# Altium schematic sheet FinalYear_Project_PCB.SchDoc
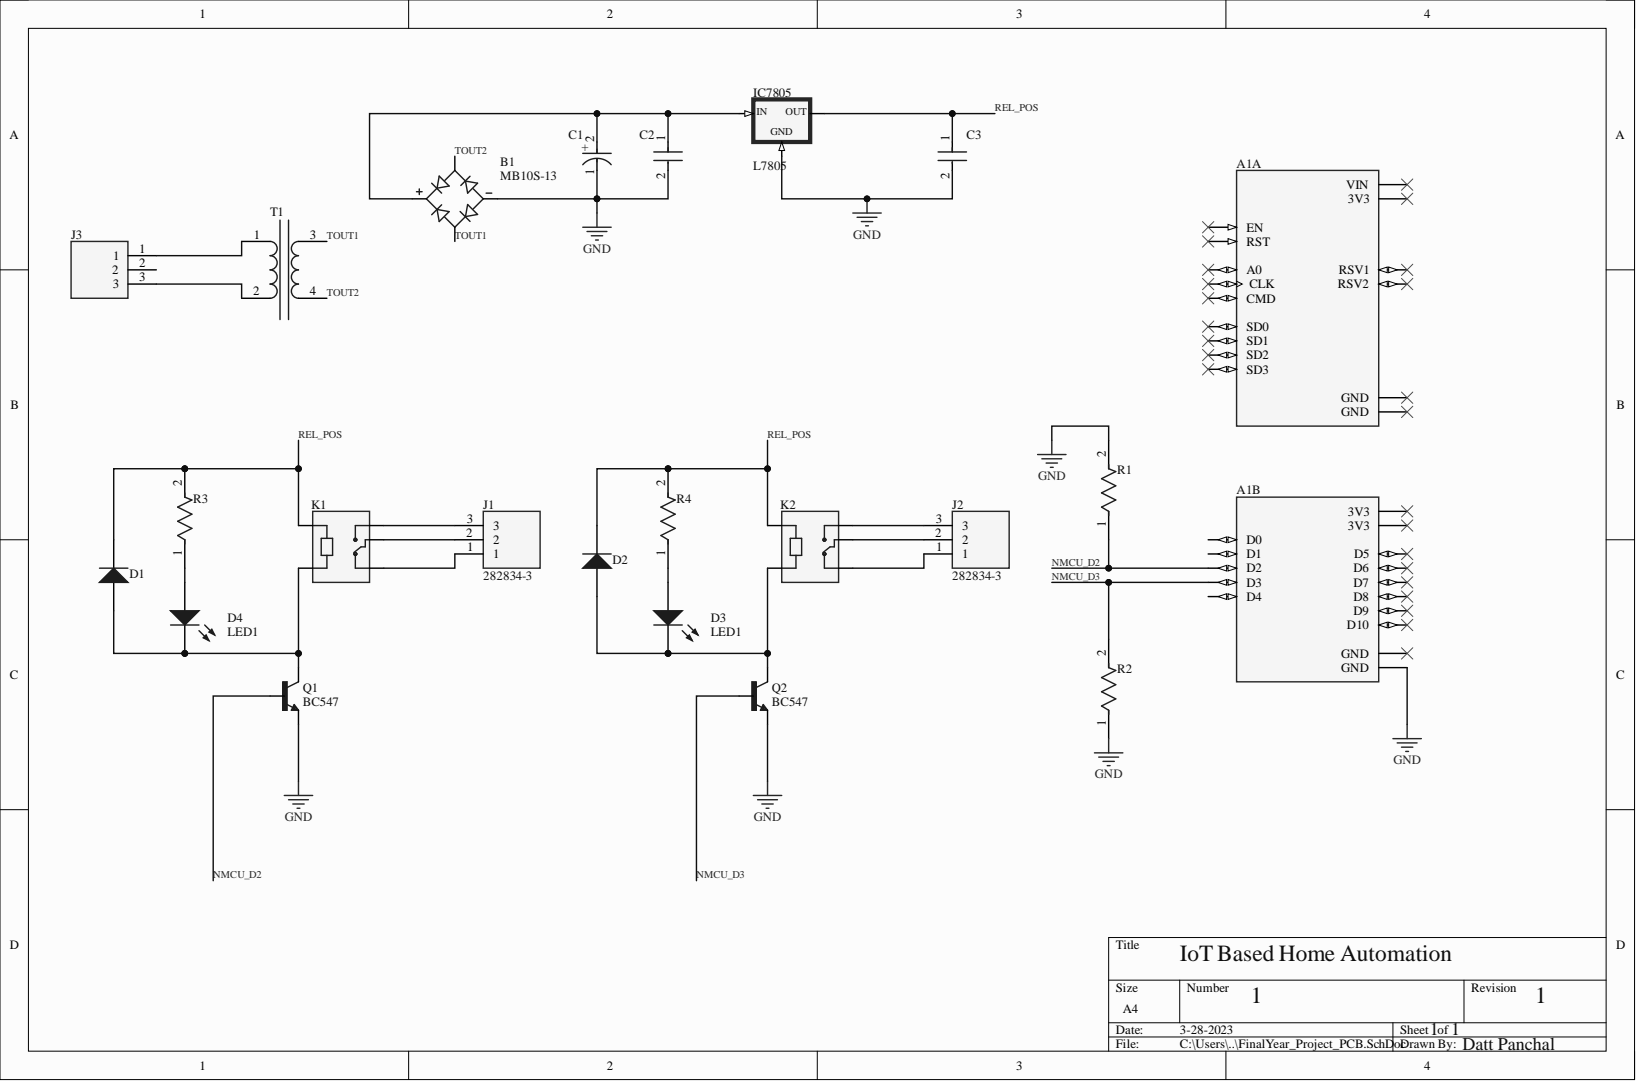
[diagram p0: FinalYear_Project_PCB.SchDoc]

## Parts
A1  113990105  [SEEED_113990105]  (value not drawn)  (parts A,B)
B1  MB10S-13  [SOIC245P670X290-4N]
C1  EEEFP1E471AP  [FP-FPG-MFG]  (value not drawn)
C2  C0603C104K5RACTU  [FP-C0603C-CF-MFG]  (value not drawn)
C3  C0603C104K5RACTU  [FP-C0603C-CF-MFG]  (value not drawn)
D1  Diode 1N4001  [DO-41]  (value not drawn)
D2  Diode 1N4001  [DO-41]  (value not drawn)
D3  LED1  [LED-1]
D4  LED1  [LED-1]
IC7805  L7805  [78XXS]
J1  282834-3  [TE_282834-3]
J2  282834-3  [TE_282834-3]
J3  282834-3  [TE_282834-3]  (value not drawn)
K1  SRD-05VDC-SL-C  [RELAY_SRD-05VDC-SL-C]  (value not drawn)
K2  SRD-05VDC-SL-C  [RELAY_SRD-05VDC-SL-C]  (value not drawn)
Q1  BC547  [TO92-CBE]
Q2  BC547  [TO92-CBE]
R1  MBB02070C6200FCT00  [FP-MBB0207-MFG]  (value not drawn)
R2  MBB02070C6200FCT00  [FP-MBB0207-MFG]  (value not drawn)
R3  MBB02070C6200FCT00  [FP-MBB0207-MFG]  (value not drawn)
R4  MBB02070C6200FCT00  [FP-MBB0207-MFG]  (value not drawn)
T1  ST-3-56  [PCBComponent_1]  (value not drawn)

## Nets
GND: A1.J2_14(GND) B1.2 C1.1 C2.2 C3.2 IC7805.GND Q1.E Q2.E R1.2 R2.1
NMCU_D2: A1.J2_3(D2) Q1.B R1.1
NMCU_D3: A1.J2_4(D3) Q2.B R2.2
REL_POS: C3.1 D1.2 D2.2 IC7805.OUT K1.A1 K2.A1 R3.2 R4.2
TOUT1: B1.3 T1.3
TOUT2: B1.4 T1.4
NetB1_1: B1.1 C1.2 C2.1 IC7805.IN
NetD1_1: D1.1 D4.2 K1.A2 Q1.C
NetD2_1: D2.1 D3.2 K2.A2 Q2.C
NetD3_1: D3.1 R4.1
NetD4_1: D4.1 R3.1
NetJ1_1: J1.1 K1.NC
NetJ1_2: J1.2 K1.COM
NetJ1_3: J1.3 K1.NO
NetJ2_1: J2.1 K2.NC
NetJ2_2: J2.2 K2.COM
NetJ2_3: J2.3 K2.NO
NetJ3_1: J3.1 T1.1
NetJ3_3: J3.3 T1.2

Not connected: A1 J1_1(A0) J1_2(RSV1) J1_3(RSV2) J1_4(SD3) J1_5(SD2) J1_6(SD1) J1_7(CMD) J1_8(SD0) J1_9(CLK) J1_10(GND) J1_11(3V3) J1_12(EN) J1_13(RST) J1_14(GND) J1_15(VIN) J2_1(D0) J2_2(D1) J2_5(D4) J2_6(3V3) J2_7(GND) J2_8(D5) J2_9(D6) J2_10(D7) J2_11(D8) J2_12(D9) J2_13(D10) J2_15(3V3); J3 2

## Library data of the parts
A1 = 113990105: - ESP8266 Transceiver; 802.11 b/g (Wi-Fi, WiFi, WLAN) 2.4GHz Evaluation Board; MF: Seeed Technology Co., Ltd; Availability: In Stock; Check_prices: https://www.snapeda.com/parts/113990105/Seeed+Technology+Co.%252C+Ltd/view-part/?ref=eda; Component Kind: Standard; Library Name: 113990105.SchLib; MP: 113990105; Package: None; Price: None; Purchase-URL: https://pricing.snapeda.com/search/part/113990105/?ref=eda; SnapEDA_Link: https://www.snapeda.com/parts/113990105/Seeed+Technology+Co.%252C+Ltd/view-part/?ref=snap
B1 = MB10S-13: Bridge Rectifier Single Phase Standard 1 kV Surface Mount MBS; MF: Diodes Inc.; Availability: In Stock; Check_prices: https://www.snapeda.com/parts/MB10S-13/Diodes+Inc./view-part/?ref=eda; Component Kind: Standard; Library Name: MB10S-13.SchLib; MP: MB10S-13; Package: BESOP-4 Diodes Inc.; Price: None; Purchase-URL: https://pricing.snapeda.com/search/part/MB10S-13/?ref=eda; SnapEDA_Link: https://www.snapeda.com/parts/MB10S-13/Diodes+Inc./view-part/?ref=snap
C1 = CMP-2000-06214-2: CAP ALUM 470UF 20% 25V SMD; ESR (Equivalent Series Resistance): 60mR; Leakage Current: 117.5uA; Life (Hours): 2000Hour; Material: Aluminium; Polarity: Polar; Ripple Current: 1.19A; Capacitance: 470uF; Case/Package: Radial; Component Kind: Standard; Construction: Cylindrical; Contact Plating: Tin; Diameter: 10mm; Height: 10.2mm; Height - Seated (Max): 0.402inch; Lead Free: Lead Free; Lead Pitch: 4.6mm; Length: 10.5mm; Library Name: Altium Content Vault; Max Operating Temperature: 105°C; Min Operating Temperature: -55°C; Mount: Surface Mount; Number of Pins: 2; Package Quantity: 500; Packaging: Tape and Reel; Radiation Hardening: No; REACH SVHC: No SVHC; Ripple Current (AC): 1.19A; RoHS Compliant: Yes; Series: FP; Termination: SMD/SMT; Tolerance: 20%; Voltage: 25V; Voltage Rating: 25V; Voltage Rating (DC): 25V; Width: 10.3mm
C2 C3 = CMP-2006-03061-2: CAP CER 0.1UF 50V X7R 0603; Manufacturer: KEMET; Automotive Grade: Grade 1; Capacitance: 0.10 μF; Material: Ceramic; Temperature Coefficient: 30ppm/°C; Tolerance: 0.1; Value: 0.10 μF; Automotive: Yes; Category: Cap; Component Kind: Standard; ComponentLink2Description: Datasheet; ComponentLink2URL: https://api.kemet.com/component-edge/download/datasheet/C0603C100J5GACAUTO.pdf; Device Class L1: Passive Components; Device Class L2: Capacitors; Device Class L3: Ceramic Capacitors; Digikey Description: CAP CER 0.1UF 50V X7R 0603; Height: 0.87mm; Lead Free: Yes; Library Name: Altium Content Vault; Manufacturer Part Number: C0603C104K5RACTU; Octopart: https://octopart.com/c0603c104k5ractu-kemet-139244; Package: C0603C-CF; RoHS: TRUE; Temperature: -55°C to +125°C; Temperature Characteristic: X7R; Temperature Range High: +125°C; Temperature Range Low: -55°C; Voltage Rating: 50 V
D1 D2 = Diode 1N4001: 1 Amp General Purpose Rectifier; Code_JEDEC: DO-204-AL; Component Kind: Standard; ComponentLink1Description: Manufacturer Link; ComponentLink1URL: http://www.fairchildsemi.com/; ComponentLink2Description: Datasheet; ComponentLink2URL: http://www.fairchildsemi.com/ds/1N%2F1N4001.pdf; DatasheetVersion: 1998; LatestRevisionDate: 17-Jul-2002; LatestRevisionNote: Re-released for DXP Platform.; Library Name: Miscellaneous Devices.IntLib; PackageDescription: Diode, Axial; Body 5.2 x 2.7 mm (LxDia. max), Lead Dia. 0.86 mm (max); PackageReference: DO-41; PackageVersion: Aug-1999; Published: 8-Jun-2000; Signal Integrity: 1N4001; Simulation: 1N4001; Publisher: Altium Limited
D3 D4 = LED1: Typical RED GaAs LED; Component Kind: Standard; LatestRevisionDate: 08-Jul-2005; LatestRevisionNote: Mech Layer 1 removed.; Library Name: Miscellaneous Devices.IntLib; PackageDescription: LED; 2 Leads; PackageReference: LED-1; Published: 8-Jun-2000; Simulation: LED1; Publisher: Altium Limited
IC7805 = L7805: Linear Voltage Regulator IC 1 Output 1.5A D2PAK; MF: STMicroelectronics; Availability: Unavailable; Component Kind: Standard; Library Name: l7805.SchLib; MP: L7805; Package: TO-263-3 Inchange Semiconductor; Price: None; SnapEDA_Link: https://www.snapeda.com/parts/L7805/STMicroelectronics/view-part/563125/?ref=snap
J1-J3 = 282834-3: MF: {'manufacturer': u'TE Connectivity'}; Availability: Unavailable; Component Kind: Standard; Library Name: 282834-3.SchLib; MP: 282834-3; Package: None; Price: None
K1 K2 = SRD-05VDC-SL-C: 5V Trigger Relay Module For Arduino And Raspberry Pi 5V Trigger Relay Module For Arduino And Raspberry Pi; MF: Songle Relay; Availability: Not in stock; Check_prices: https://www.snapeda.com/parts/SRD-05VDC-SL-C/Songle+Relay/view-part/?ref=eda; Component Kind: Standard; Library Name: SRD-05VDC-SL-C.SchLib; MP: SRD-05VDC-SL-C; Package: NON STANDARD-5 Songle Relay; Price: None; SnapEDA_Link: https://www.snapeda.com/parts/SRD-05VDC-SL-C/Songle+Relay/view-part/?ref=snap
Q1 Q2 = BC547: Trans GP BJT NPN 45V 0.1A 500mW 3-Pin TO-92 T/R; MF: ON Semiconductor; Availability: In Stock; Check_prices: https://www.snapeda.com/parts/BC547/Onsemi/view-part/?ref=eda; Component Kind: Standard; Library Name: BC547.SchLib; MP: BC547; Package: None; Price: None; Purchase-URL: https://pricing.snapeda.com/search/part/BC547/?ref=eda; SnapEDA_Link: https://www.snapeda.com/parts/BC547/Onsemi/view-part/?ref=snap
R1-R4 = CMP-02408-000026-1: RES 620 OHM 0.6W 1% AXIAL; Max Power Dissipation: 600mW; Resistance: 620R; Temperature Coefficient: 50ppm/°C; Case/Package: Axial; Component Kind: Standard; Construction: Cylindrical; Contact Plating: Tin; Diameter: 2.5mm; Features: Automotive AEC-Q200; Lead Free: Lead Free; Length: 6.5mm; Library Name: Altium Content Vault; Max Operating Temperature: 155°C; Military Standard: Not; Min Operating Temperature: -55°C; Mount: Through Hole; Number of Terminations: 2; Packaging: Tape and Reel; Power Rating: 600mW; Radiation Hardening: No; Resistor Type: Metal Film; RoHS Compliant: Yes; Series: MBB 0207 - Professional; Technology: THIN FILM; Termination: Axial; Tolerance: 1%
T1 = ST-3-56: Split Bobbin Low Power Transformer | 2.4VA Power | 115V Input | 10VCT Output Series; Availability: Unavailable; Component Kind: Standard; Library Name: uc30s.SchLib; MP: ST-3-56; Package: None; Price: None
